# Altium schematic sheet Bleeding.SchDoc(Bleeding)
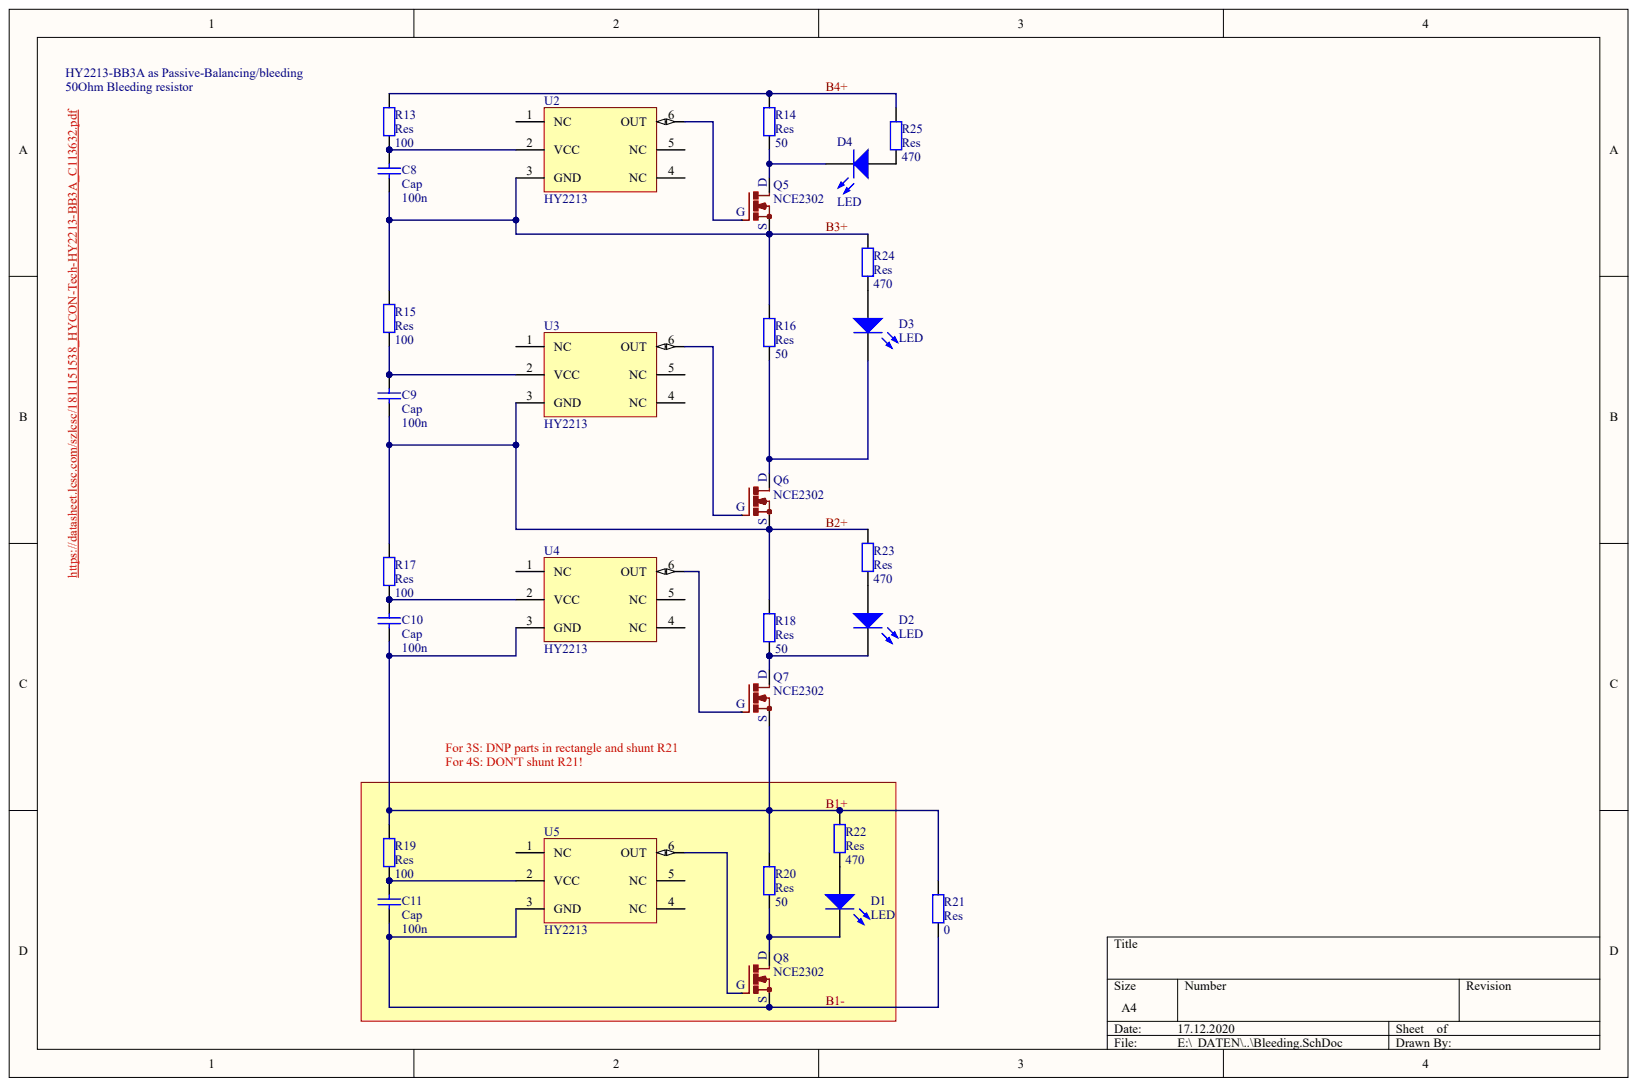
[diagram p0: Bleeding.SchDoc]

## Parts
C8  Cap  value 100n
C9  Cap  value 100n
C10  Cap  value 100n
C11  Cap  value 100n
D1  LED
D2  LED
D3  LED
D4  LED
Q5  NCE2302
Q6  NCE2302
Q7  NCE2302
Q8  NCE2302
R13  Res  value 100
R14  Res  value 50
R15  Res  value 100
R16  Res  value 50
R17  Res  value 100
R18  Res  value 50
R19  Res  value 100
R20  Res  value 50
R21  Res  value 0
R22  Res  value 470
R23  Res  value 470
R24  Res  value 470
R25  Res  value 470
U2  HY2213
U3  HY2213
U4  HY2213
U5  HY2213

## Nets
B1+: C10.1 Q7.2 R19.2 R20.2 R21.2 R22.2 U4.3(GND)
B1-: C11.1 Q8.2 R21.1 U5.3(GND)
B2+: C9.1 Q6.2 R17.2 R18.2 R23.2 U3.3(GND)
B3+: C8.1 Q5.2 R15.2 R16.2 R24.2 U2.3(GND)
B4+: R13.2 R14.2 R25.2
NetC8_2: C8.2 R13.1 U2.2(VCC)
NetC9_2: C9.2 R15.1 U3.2(VCC)
NetC10_2: C10.2 R17.1 U4.2(VCC)
NetC11_2: C11.2 R19.1 U5.2(VCC)
NetD1_1: D1.1 Q8.3 R20.1
NetD1_2: D1.2 R22.1
NetD2_1: D2.1 Q7.3 R18.1
NetD2_2: D2.2 R23.1
NetD3_1: D3.1 Q6.3 R16.1
NetD3_2: D3.2 R24.1
NetD4_1: D4.1 Q5.3 R14.1
NetD4_2: D4.2 R25.1
NetQ5_1: Q5.1 U2.6(OUT)
NetQ6_1: Q6.1 U3.6(OUT)
NetQ7_1: Q7.1 U4.6(OUT)
NetQ8_1: Q8.1 U5.6(OUT)

Not connected: U2 1(NC) 4(NC) 5(NC); U3 1(NC) 4(NC) 5(NC); U4 1(NC) 4(NC) 5(NC); U5 1(NC) 4(NC) 5(NC)

## Library data of the parts
D1-D4 = (no symbol name): PCB_Layout: Complies with manufacturer's recommendation.; Published: 14-Mar-2008; DatasheetVersion: Mar-2007; PackageVersion: Mar-2007; PackageReference: LWP4SG; PackageDescription: White LED; 2 Reverse Gullwing Leads, Body 1.9 x 0.875mm (Dia.xH); ComponentLink1Description: Manufacturer Link; ComponentLink1URL: http://www.osram-os.com/; ComponentLink2Description: Datasheet; ComponentLink2URL: http://catalog.osram-os.com/catalogue/catalogue.do;jsessionid=AD571800CED0208623E7FAFDF556DB1C?act=downloadFile&favOid=020000030001e106000200b6; Publisher: Altium Limited
Q5-Q8 = (no symbol name): Note: Pin 2 is electrically connected to pin 4.; Published: 8-Jun-2000; LatestRevisionDate: 17-Jul-2002; LatestRevisionNote: Re-released for DXP Platform.; PackageReference: TO-251AA; PackageDescription: TO, Thru-Hole, Vertical, Heatsink Mounted; 3 In-Line Leads; Pitch 2.28 mm; ComponentLink1Description: Manufacturer Link; ComponentLink1URL: http://www.irf.com/; ComponentLink2Description: Datasheet; ComponentLink2URL: http://www.irf.com/product-info/datasheets/data/irlr024n.pdf; Publisher: Altium Limited
C8-C11 R13-R25 U2-U5 = (no symbol name)
  C8-C11: Published: 8-Jun-2000; LatestRevisionDate: 17-Jul-2002; LatestRevisionNote: Re-released for DXP Platform.; PackageReference: RAD-0.3; PackageDescription: Radial Cap, Thru-Hole; 2 Leads; 0.3 in Pin Spacing
  R13-R25: Published: 8-Jun-2000; LatestRevisionDate: 17-Jul-2002; LatestRevisionNote: Re-released for DXP Platform.; PackageReference: AXIAL-0.4; PackageDescription: Axial Device, Thru-Hole; 2 Leads; 0.4 in Pin Spacing
  C8-C11 R13-R25: Publisher: Altium Limited
